# Altium schematic sheet Sata_SSD1.SchDoc(Sata_SSD1)
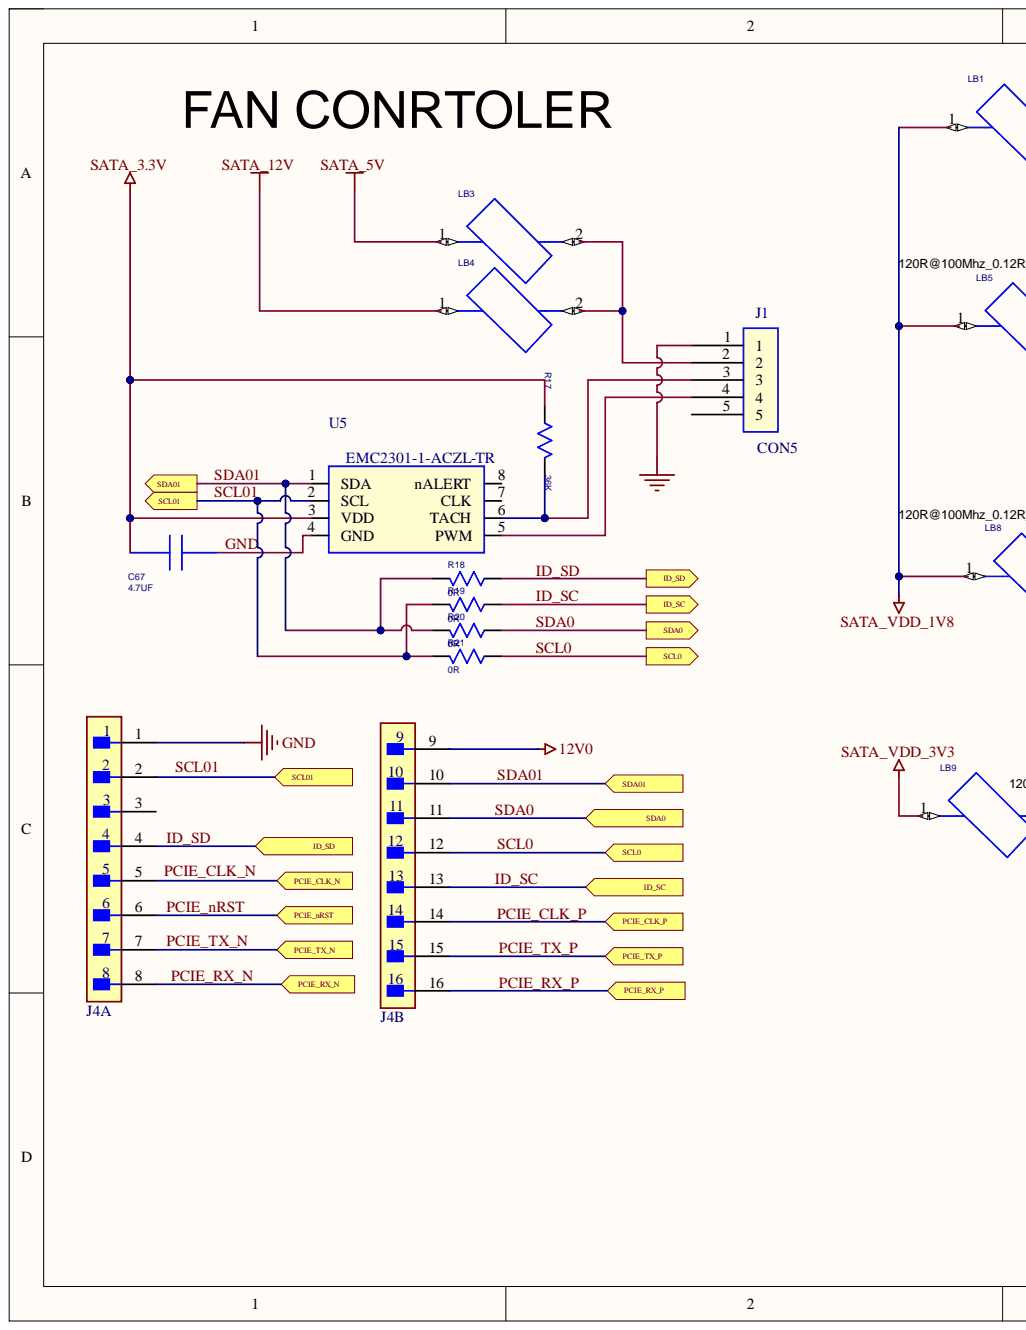
[diagram p0: Sata_SSD1.SchDoc, left]
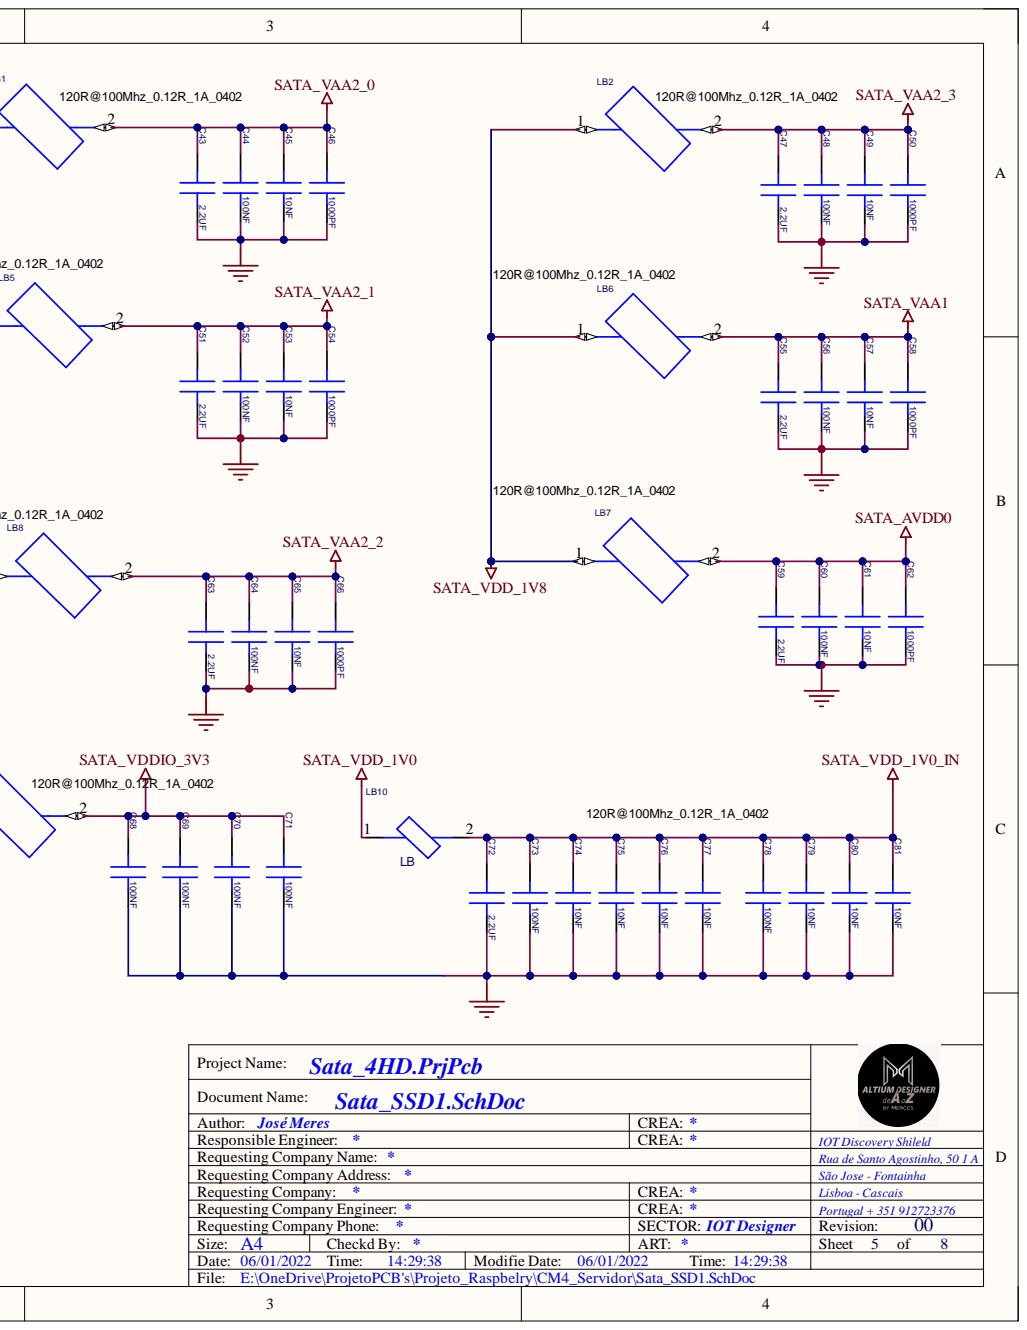
[diagram p1: Sata_SSD1.SchDoc, right]

## Parts
C43  2.2UF  [C0402-ROUND]
C44  100NF  [C0402-ROUND]
C45  10NF  [C0402-ROUND]
C46  1000PF  [C0402-ROUND]
C47  2.2UF  [C0402-ROUND]
C48  100NF  [C0402-ROUND]
C49  10NF  [C0402-ROUND]
C50  1000PF  [C0402-ROUND]
C51  2.2UF  [C0402-ROUND]
C52  100NF  [C0402-ROUND]
C53  10NF  [C0402-ROUND]
C54  1000PF  [C0402-ROUND]
C55  2.2UF  [C0402-ROUND]
C56  100NF  [C0402-ROUND]
C57  10NF  [C0402-ROUND]
C58  1000PF  [C0402-ROUND]
C59  2.2UF  [C0402-ROUND]
C60  100NF  [C0402-ROUND]
C61  10NF  [C0402-ROUND]
C62  1000PF  [C0402-ROUND]
C63  2.2UF  [C0402-ROUND]
C64  100NF  [C0402-ROUND]
C65  10NF  [C0402-ROUND]
C66  1000PF  [C0402-ROUND]
C67  4.7UF  [C0402-ROUND]
C68  100NF  [C0402-ROUND]
C69  100NF  [C0402-ROUND]
C70  100NF  [C0402-ROUND]
C71  100NF  [C0402-ROUND]
C72  2.2UF  [C0402-ROUND]
C73  100NF  [C0402-ROUND]
C74  10NF  [C0402-ROUND]
C75  10NF  [C0402-ROUND]
C76  10NF  [C0402-ROUND]
C77  10NF  [C0402-ROUND]
C78  100NF  [C0402-ROUND]
C79  10NF  [C0402-ROUND]
C80  10NF  [C0402-ROUND]
C81  10NF  [C0402-ROUND]
J1  CON5  [HDR1X4 M - Especial]
J4  43045-1612  [MOLEX_43045-1627]  (value not drawn)  (parts A,B)
LB1  LB  [L0402$2F$$2F$A]
LB2  LB  [L0402$2F$$2F$A]
LB3  LB/NC  [L0402$2F$$2F$A]  (value not drawn)
LB4  LB  [L0402$2F$$2F$A]
LB5  LB  [L0402$2F$$2F$A]
LB6  LB  [L0402$2F$$2F$A]
LB7  LB  [L0402$2F$$2F$A]
LB8  LB  [L0402$2F$$2F$A]
LB9  LB  [L0402$2F$$2F$A]
LB10  LB  [L0805-ROUND]
R17  36K  [R0402-ROUND]
R18  0R  [R0402-ROUND]
R19  0R  [R0402-ROUND]
R20  0R  [R0402-ROUND]
R21  0R  [R0402-ROUND]
U5  EMC2301-1-ACZL-TR  [SO08]

## Ports
ID_SC, ID_SD, PCIE_CLK_N, PCIE_CLK_P, PCIE_nRST, PCIE_RX_N, PCIE_RX_P, PCIE_TX_N, PCIE_TX_P, SCL0, SCL01, SDA0, SDA01

## Nets
12V0: J4.9
SATA_3.3V: C67.1 R17.1 U5.3(VDD)
SATA_5V: LB3.1
SATA_12V: LB4.1
SATA_AVDD0: C59.1 C60.1 C61.1 C62.1 LB7.2
SATA_VAA1: C55.1 C56.1 C57.1 C58.1 LB6.2
SATA_VAA2_0: C43.1 C44.1 C45.1 C46.1 LB1.2
SATA_VAA2_1: C51.1 C52.1 C53.1 C54.1 LB5.2
SATA_VAA2_2: C63.1 C64.1 C65.1 C66.1 LB8.2
SATA_VAA2_3: C47.1 C48.1 C49.1 C50.1 LB2.2
SATA_VDD_1V0: LB10.1
SATA_VDD_1V0_IN: C72.1 C73.1 C74.1 C75.1 C76.1 C77.1 C78.1 C79.1 C80.1 C81.1 LB10.2
SATA_VDD_1V8: LB1.1 LB2.1 LB5.1 LB6.1 LB7.1 LB8.1
SATA_VDD_3V3: LB9.1
SATA_VDDIO_3V3: C68.1 C69.1 C70.1 C71.1 LB9.2
GND: C43.2 C44.2 C45.2 C46.2 C47.2 C48.2 C49.2 C50.2 C51.2 C52.2 C53.2 C54.2 C55.2 C56.2 C57.2 C58.2 C59.2 C60.2 C61.2 C62.2 C63.2 C64.2 C65.2 C66.2 C67.2 C68.2 C69.2 C70.2 C71.2 C72.2 C73.2 C74.2 C75.2 C76.2 C77.2 C78.2 C79.2 C80.2 C81.2 J1.1 J4.1 U5.4(GND)
ID_SC: J4.13 R19.1 ; port ID_SC
ID_SD: J4.4 R18.1 ; port ID_SD
PCIE_CLK_N: J4.5 ; port PCIE_CLK_N
PCIE_CLK_P: J4.14 ; port PCIE_CLK_P
PCIE_nRST: J4.6 ; port PCIE_nRST
PCIE_RX_N: J4.8 ; port PCIE_RX_N
PCIE_RX_P: J4.16 ; port PCIE_RX_P
PCIE_TX_N: J4.7 ; port PCIE_TX_N
PCIE_TX_P: J4.15 ; port PCIE_TX_P
SCL0: J4.12 R21.1 ; port SCL0
SCL01: J4.2 R19.2 R21.2 U5.2(SCL) ; port SCL01
SDA0: J4.11 R20.1 ; port SDA0
SDA01: J4.10 R18.2 R20.2 U5.1(SDA) ; port SDA01
NetJ1_2: J1.2 LB3.2 LB4.2
NetJ1_3: J1.3 R17.2 U5.6(TACH)
NetJ1_4: J1.4 U5.5(PWM)
PCIE_CLK_N:

Not connected: J1 5; J4 3; U5 7(CLK) 8(nALERT)

## Library data of the parts
C43 C47 C51 C55 C59 C63 C72 = C_0_Dup3: PARTS: 1; %182%%238%%182%%168%%185%%164%%215%%247%%181%%231%%209%%185%(V): 6.3; %195%%232%%202%%246%: SMD陶瓷电容-6.3V-2200nF-+/-10%-X7S-0402; %208%%161%%192%%224%%195%%251%%179%%198%: SMD陶瓷电容; CODE: 08071990; COMPONENT_HIGH_MAX: 0.65mm; DEVICE: CM05X6T475M06AH-HW; LEVEL: STD; OriginalSymbolOrigin: (0,30); PCB Footprint: SC0402-BGA; PINSWAP: (A,B); PKG_TYPE: SC0402; Component Kind: Standard
C44-C46 C48-C50 C52-C54 C56-C58 C60-C62 C64-C66 C68-C71 C73-C81 = C_0_Dup3: PARTS: 1; %182%%238%%182%%168%%185%%164%%215%%247%%181%%231%%209%%185%(V): 16; %195%%232%%202%%246%: SMD陶瓷电容-16V-100NF-+/-10%-X7R-0402-BT; %208%%161%%192%%224%%195%%251%%179%%198%: SMD陶瓷电容; CODE: 8070614; COMPONENT_HIGH_MAX: 0.55MM; DEVICE: RMEMK105BJ104KV_FR; LEVEL: STD; OriginalSymbolOrigin: (0,30); PCB Footprint: SC0402; PINSWAP: (A,B); VARIANT_ID: $37I1928; Component Kind: Standard; PKG_TYPE: SC0402
C67 = C_4: PARTS: 1; %182%%238%%182%%168%%185%%164%%215%%247%%181%%231%%209%%185%(V): 6.3; %195%%232%%202%%246%: SMD陶瓷电容-6.3V-4700nF-+/-20%-X5R-0402; %208%%161%%192%%224%%195%%251%%179%%198%: SMD陶瓷电容; CODE: 8071610; COMPONENT_HIGH_MAX: 0.65mm; DEVICE: C1005X5R0J475MTJ00F; LEVEL: STD; OriginalSymbolOrigin: (7,-5); PCB Footprint: HSC0402; PINSWAP: (1,2); Component Kind: Standard; PKG_TYPE: HSC0402
J1 = CON5: PCB Footprint: wafer_1x4_2d54_th; Component Kind: Standard
LB1-LB9 = LB: PARTS: 1; %195%%232%%202%%246%: EMI磁珠-+/-25%-1000OHM-1.25OHM-0.25A-0402-1400OHM@1GHZ; %208%%161%%192%%224%%195%%251%%179%%198%: EMI磁珠; CODE: 10070019; COMPONENT_HIGH_MAX: 0.65MM; DEVICE: BLM15HG102SN1D; LEVEL: STD; OriginalSymbolOrigin: (10,60); PCB Footprint: SL0402; Component Kind: Standard; PKG_TYPE: SL0402
LB10 = LB_2: PARTS: 1; %195%%232%%202%%246%: EMI磁珠-+/-25%-220OHM-0.05OHM-2A-0805; %208%%161%%192%%224%%195%%251%%179%%198%: EMI磁珠; CODE: 10070012; COMPONENT_HIGH_MAX: 1.05MM; DEVICE: MGLB2012_220T_LF; LEVEL: STD; OriginalSymbolOrigin: (20,50); PCB Footprint: SL0805; Component Kind: Standard; PKG_TYPE: SL0805
R17-R21 = R_1: PARTS: 1; %195%%232%%202%%246%: 片式厚膜电阻器-0.0625W-2000OHM-+/-1%-0402--供电专用-07091354; %208%%161%%192%%224%%195%%251%%179%%198%: 片式厚膜电阻器; CODE: 7091529; COMPONENT_HIGH_MAX: 999999MM; DEVICE: RC0402FR_072KL; LEVEL: STD; OriginalSymbolOrigin: (10,20); PCB Footprint: SR0402; PINSWAP: (A,B); Component Kind: Standard; PKG_TYPE: SR0402
U5 = EMC2301-1-ACZL-TR_0: PARTS: 1; CODE: 39130057; LEVEL: STD; OriginalSymbolOrigin: (0,-5); PCB Footprint: TSSOP8_P0D65_W3; PKG_TYPE: SOP8-50-173; Component Kind: Standard; DEVICE: EMC2301-1-ACZL-TR
J4 = 43045-1612: Component Kind: Standard; Library Name: Merces_Library.SchLib
